# Altium schematic sheet [05] - PMOD HEADERS.SchDoc("[05] - PMOD HEADERS")
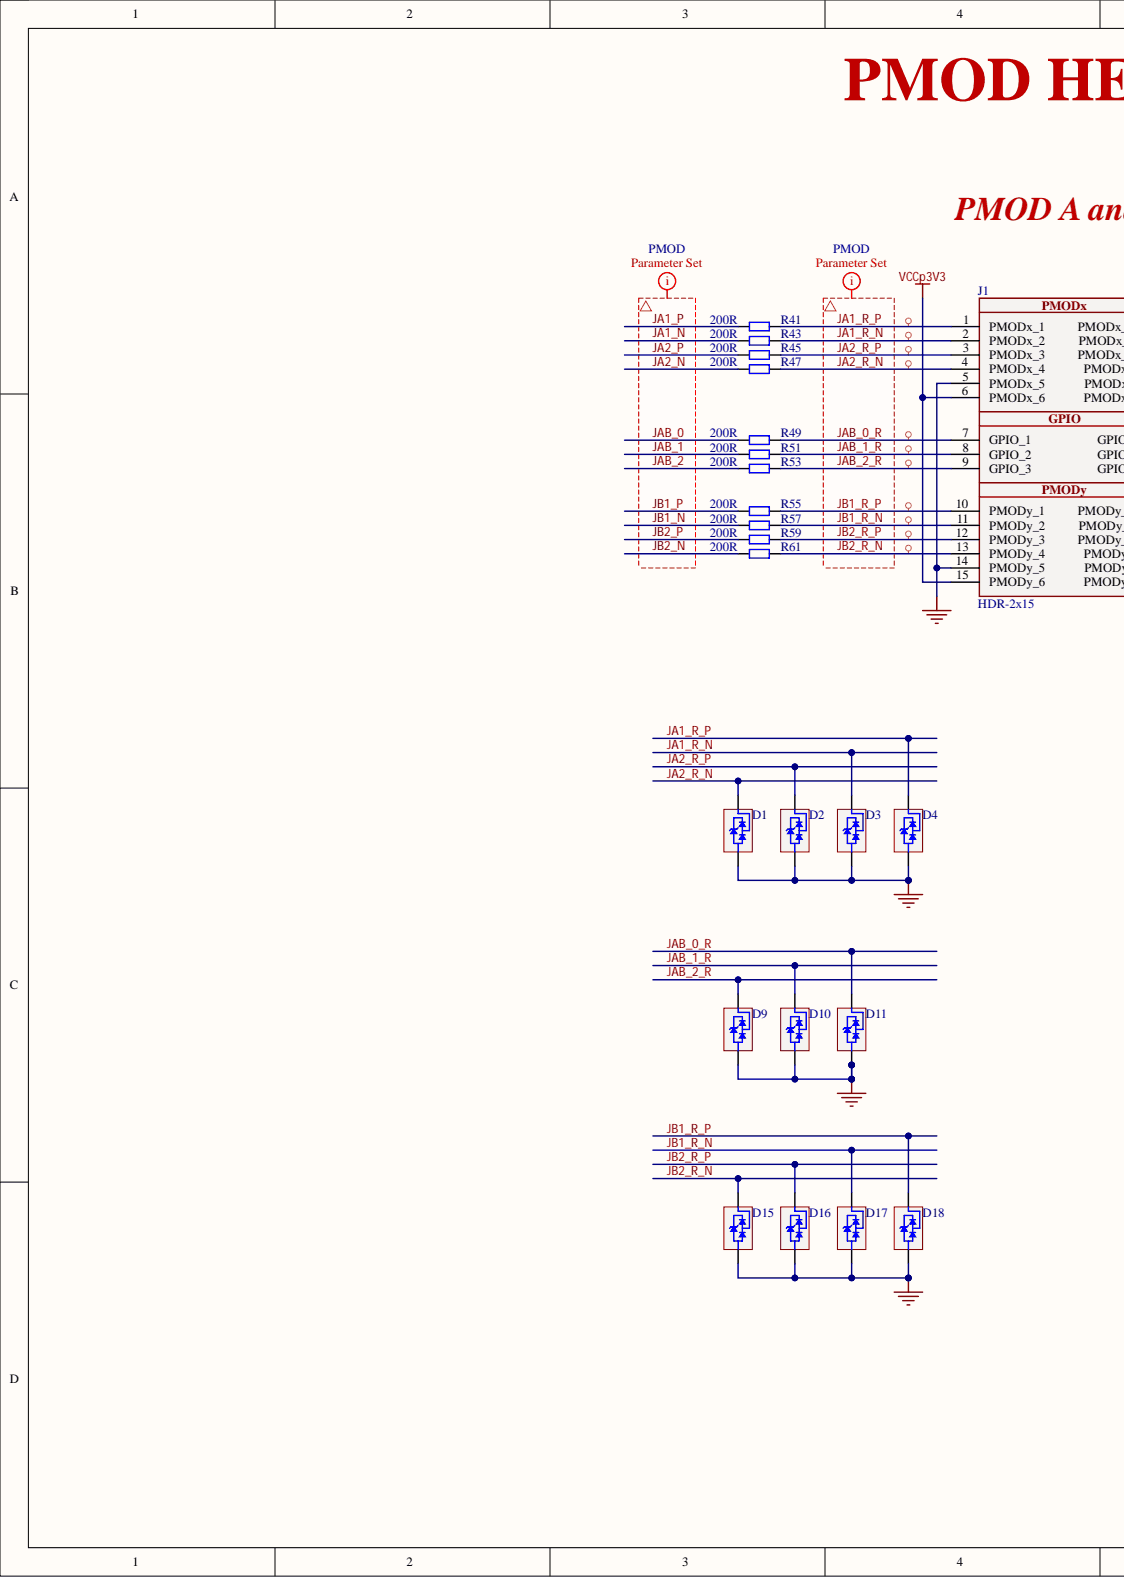
[diagram p0: [05] - PMOD HEADERS.SchDoc, left]
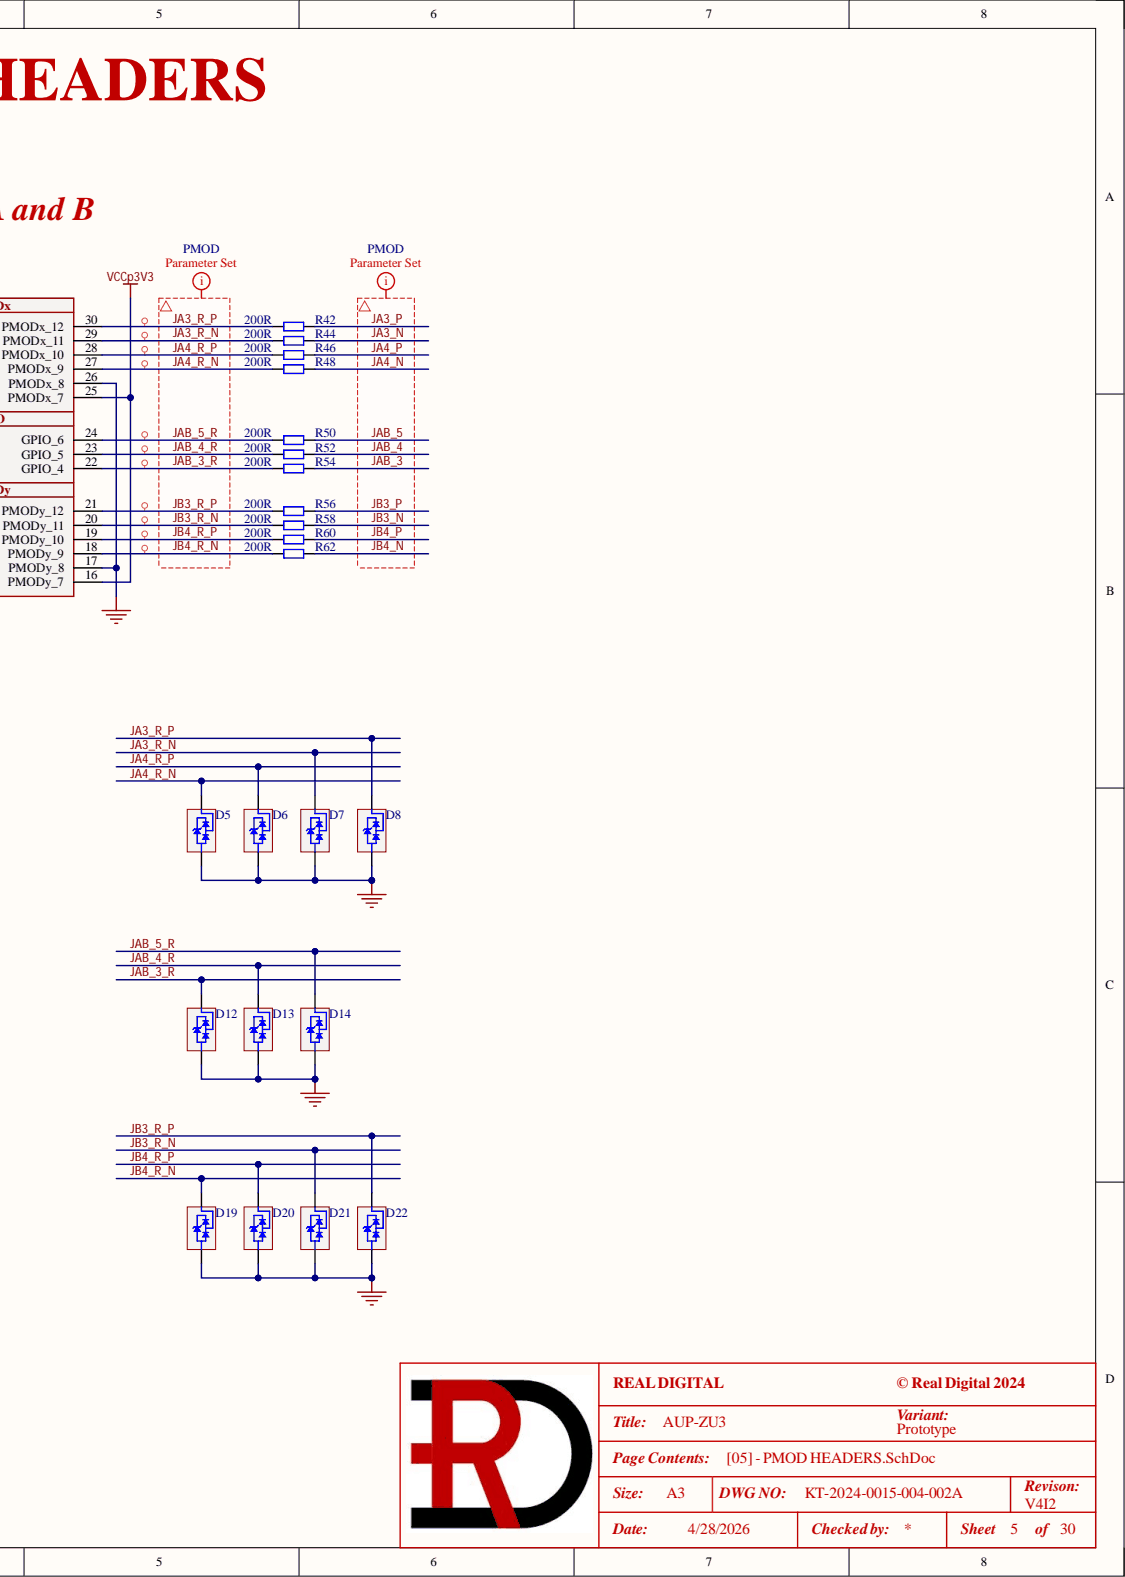
[diagram p1: [05] - PMOD HEADERS.SchDoc, right]

## Parts
D1  TPD1E05U06DPYR  [DPY0002A - TI]  (value not drawn)
D2  TPD1E05U06DPYR  [DPY0002A - TI]  (value not drawn)
D3  TPD1E05U06DPYR  [DPY0002A - TI]  (value not drawn)
D4  TPD1E05U06DPYR  [DPY0002A - TI]  (value not drawn)
D5  TPD1E05U06DPYR  [DPY0002A - TI]  (value not drawn)
D6  TPD1E05U06DPYR  [DPY0002A - TI]  (value not drawn)
D7  TPD1E05U06DPYR  [DPY0002A - TI]  (value not drawn)
D8  TPD1E05U06DPYR  [DPY0002A - TI]  (value not drawn)
D9  TPD1E05U06DPYR  [DPY0002A - TI]  (value not drawn)
D10  TPD1E05U06DPYR  [DPY0002A - TI]  (value not drawn)
D11  TPD1E05U06DPYR  [DPY0002A - TI]  (value not drawn)
D12  TPD1E05U06DPYR  [DPY0002A - TI]  (value not drawn)
D13  TPD1E05U06DPYR  [DPY0002A - TI]  (value not drawn)
D14  TPD1E05U06DPYR  [DPY0002A - TI]  (value not drawn)
D15  TPD1E05U06DPYR  [DPY0002A - TI]  (value not drawn)
D16  TPD1E05U06DPYR  [DPY0002A - TI]  (value not drawn)
D17  TPD1E05U06DPYR  [DPY0002A - TI]  (value not drawn)
D18  TPD1E05U06DPYR  [DPY0002A - TI]  (value not drawn)
D19  TPD1E05U06DPYR  [DPY0002A - TI]  (value not drawn)
D20  TPD1E05U06DPYR  [DPY0002A - TI]  (value not drawn)
D21  TPD1E05U06DPYR  [DPY0002A - TI]  (value not drawn)
D22  TPD1E05U06DPYR  [DPY0002A - TI]  (value not drawn)
J1  HDR-2x15  [PMOD_X2_PLUS_6_V2]
R41  200R  [R0402]
R42  200R  [R0402]
R43  200R  [R0402]
R44  200R  [R0402]
R45  200R  [R0402]
R46  200R  [R0402]
R47  200R  [R0402]
R48  200R  [R0402]
R49  200R  [R0402]
R50  200R  [R0402]
R51  200R  [R0402]
R52  200R  [R0402]
R53  200R  [R0402]
R54  200R  [R0402]
R55  200R  [R0402]
R56  200R  [R0402]
R57  200R  [R0402]
R58  200R  [R0402]
R59  200R  [R0402]
R60  200R  [R0402]
R61  200R  [R0402]
R62  200R  [R0402]

## Nets
GND: D1.2 D2.2 D3.2 D4.2 D5.2 D6.2 D7.2 D8.2 D9.2 D10.2 D11.2 D12.2 D13.2 D14.2 D15.2 D16.2 D17.2 D18.2 D19.2 D20.2 D21.2 D22.2 J1.5(PMODx_5) J1.14(PMODy_5) J1.17(PMODy_8) J1.26(PMODx_8)
VCCp3V3: J1.6(PMODx_6) J1.15(PMODy_6) J1.16(PMODy_7) J1.25(PMODx_7)
JA1_N: R43.1
JA1_P: R41.1
JA1_R_N: D3.1 J1.2(PMODx_2) R43.2
JA1_R_P: D4.1 J1.1(PMODx_1) R41.2
JA2_N: R47.1
JA2_P: R45.1
JA2_R_N: D1.1 J1.4(PMODx_4) R47.2
JA2_R_P: D2.1 J1.3(PMODx_3) R45.2
JA3_N: R44.2
JA3_P: R42.2
JA3_R_N: D7.1 J1.29(PMODx_11) R44.1
JA3_R_P: D8.1 J1.30(PMODx_12) R42.1
JA4_N: R48.2
JA4_P: R46.2
JA4_R_N: D5.1 J1.27(PMODx_9) R48.1
JA4_R_P: D6.1 J1.28(PMODx_10) R46.1
JAB_0: R49.1
JAB_0_R: D11.1 J1.7(GPIO_1) R49.2
JAB_1: R51.1
JAB_1_R: D10.1 J1.8(GPIO_2) R51.2
JAB_2: R53.1
JAB_2_R: D9.1 J1.9(GPIO_3) R53.2
JAB_3: R54.2
JAB_3_R: D12.1 J1.22(GPIO_4) R54.1
JAB_4: R52.2
JAB_4_R: D13.1 J1.23(GPIO_5) R52.1
JAB_5: R50.2
JAB_5_R: D14.1 J1.24(GPIO_6) R50.1
JB1_N: R57.1
JB1_P: R55.1
JB1_R_N: D17.1 J1.11(PMODy_2) R57.2
JB1_R_P: D18.1 J1.10(PMODy_1) R55.2
JB2_N: R61.1
JB2_P: R59.1
JB2_R_N: D15.1 J1.13(PMODy_4) R61.2
JB2_R_P: D16.1 J1.12(PMODy_3) R59.2
JB3_N: R58.2
JB3_P: R56.2
JB3_R_N: D21.1 J1.20(PMODy_11) R58.1
JB3_R_P: D22.1 J1.21(PMODy_12) R56.1
JB4_N: R62.2
JB4_P: R60.2
JB4_R_N: D19.1 J1.18(PMODy_9) R62.1
JB4_R_P: D20.1 J1.19(PMODy_10) R60.1

## Library data of the parts
D1-D22 = TPD1E05U06DPYR: TVS DIODE 5.5VWM 14VC 2X1SON; Manufacturer 1: Texas Instruments; .Checked_PCB: RV; C P/N: RD-121-0534; LoadStatus: Load; Category: TVS - Diodes; Component Kind: Standard; Library Name: KT-RealDigital.IntLib; Manufacturer 1 Part Number: TPD1E05U06DPYR; .Checked_SCH: RV; .Creator: RV
J1 = PMODx2_Plus_6: Header 2.54mm, 2x15; Manufacturer 1: Morlinkom; Manufacturer 1 Part Number: 230-30; .Checked_PCB: RV; C P/N: 163-0392; LoadStatus: Load; Category: Connectors; Component Kind: Standard; Library Name: KT-RealDigital.IntLib; .Checked_SCH: RV; .Creator: RV
R41-R62 = RC0402JR-07200RL: RES SMD 200 OHM 5% 1/16W 0402; Manufacturer 1: Yageo; .Checked_PCB: AC; .Creator: RV; C P/N: 101-0045; LoadStatus: Load; Tolerance: 5%/TK100; Category: Resistors; Component Kind: Standard; Library Name: KT-RealDigital.IntLib; Manufacturer 1 Part Number: RC0402JR-07200RL; .Checked_SCH: AC
